# Altium schematic sheet Schematic.SchDoc(Schematic)
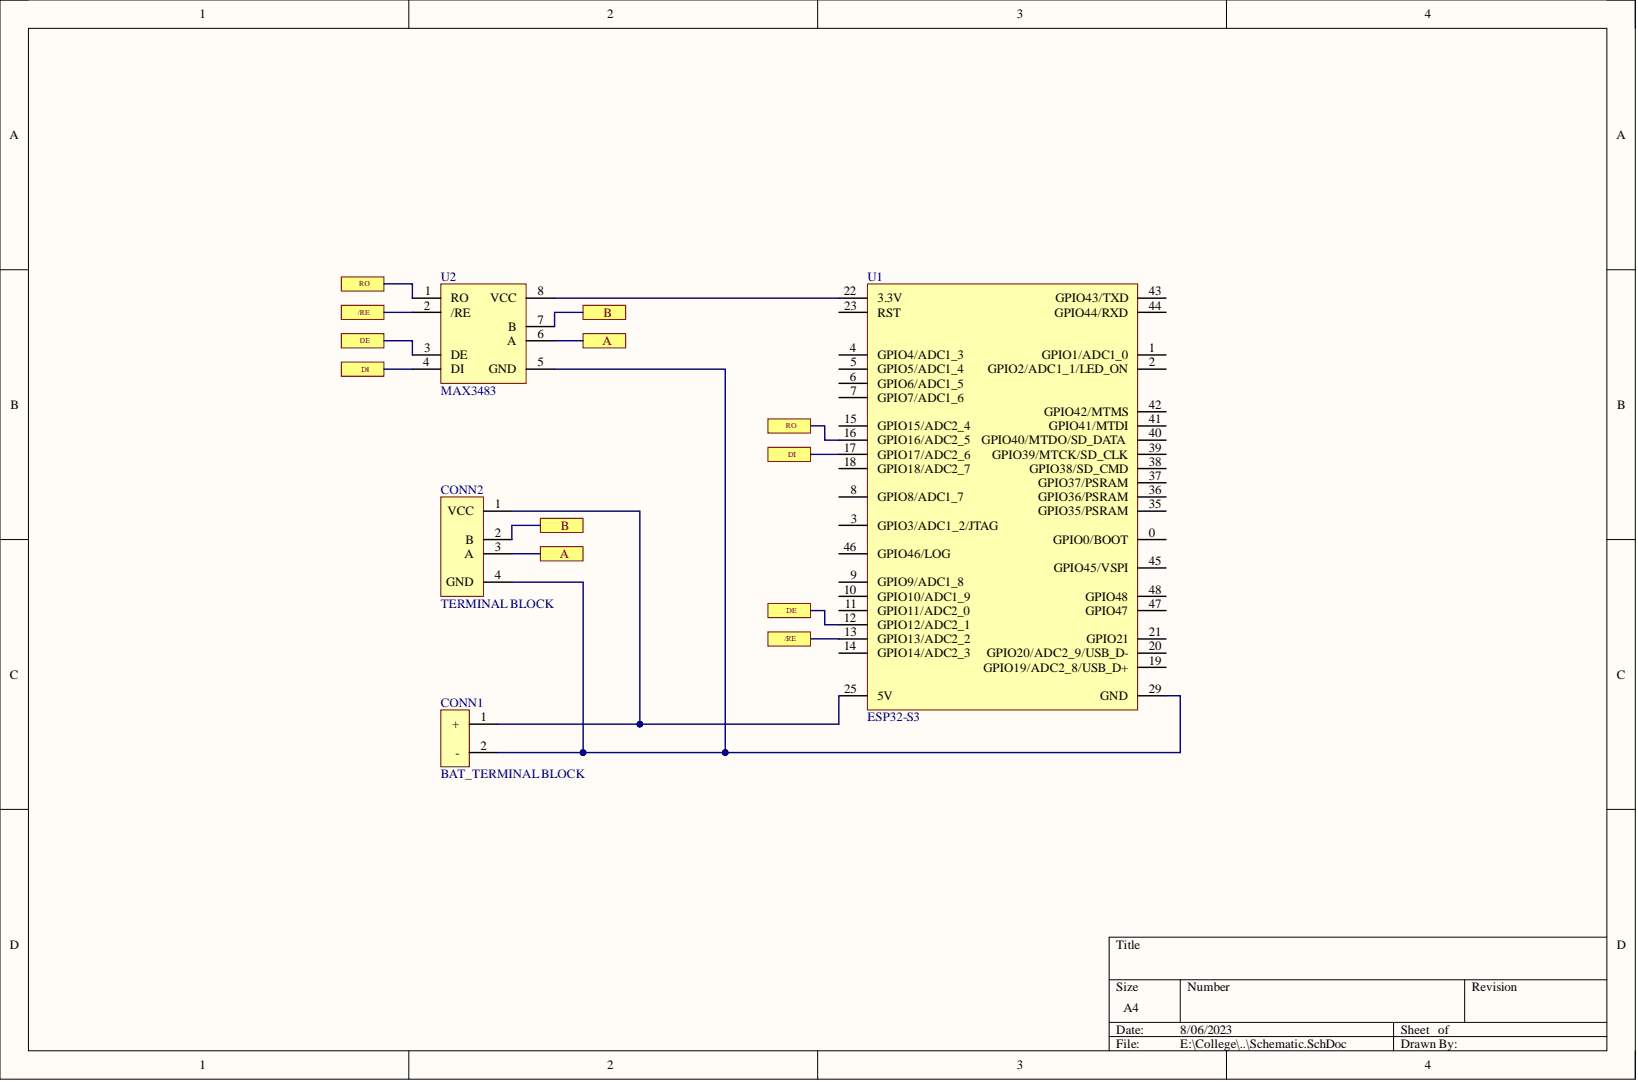
[diagram p0: Schematic.SchDoc]

## Parts
CONN1  BAT_TERMINAL BLOCK  [TERM_BLOCK2]
CONN2  TERMINAL BLOCK  [TERM_BLOCK4]
U1  ESP32-S3  [ESP32_FOOT]
U2  MAX3483  [MAX3483_FOOT]

## Ports
/RE, A, B, DE, DI, RO

## Nets
/RE: U1.13(GPIO13/ADC2_2) U2.2(/RE) ; port /RE
A: CONN2.3(A) U2.6(A) ; port A
B: CONN2.2(B) U2.7(B) ; port B
DE: U1.12(GPIO12/ADC2_1) U2.3(DE) ; port DE
DI: U1.17(GPIO17/ADC2_6) U2.4(DI) ; port DI
RO: U1.16(GPIO16/ADC2_5) U2.1(RO) ; port RO
NetCONN1_1: CONN1.1 CONN2.1(VCC) U1.25(5V)
NetCONN1_2: CONN1.2 CONN2.4(GND) U1.29(GND) U2.5(GND)
NetU1_22: U1.22(3.3V) U2.8(VCC)

Not connected: U1 0(GPIO0/BOOT) 1(GPIO1/ADC1_0) 2(GPIO2/ADC1_1/LED_ON) 3(GPIO3/ADC1_2/JTAG) 4(GPIO4/ADC1_3) 5(GPIO5/ADC1_4) 6(GPIO6/ADC1_5) 7(GPIO7/ADC1_6) 8(GPIO8/ADC1_7) 9(GPIO9/ADC1_8) 10(GPIO10/ADC1_9) 11(GPIO11/ADC2_0) 14(GPIO14/ADC2_3) 15(GPIO15/ADC2_4) 18(GPIO18/ADC2_7) 19(GPIO19/ADC2_8/USB_D+) 20(GPIO20/ADC2_9/USB_D-) 21(GPIO21) 23(RST) 35(GPIO35/PSRAM) 36(GPIO36/PSRAM) 37(GPIO37/PSRAM) 38(GPIO38/SD_CMD) 39(GPIO39/MTCK/SD_CLK) 40(GPIO40/MTDO/SD_DATA) 41(GPIO41/MTDI) 42(GPIO42/MTMS) 43(GPIO43/TXD) 44(GPIO44/RXD) 45(GPIO45/VSPI) 46(GPIO46/LOG) 47(GPIO47) 48(GPIO48)

## Library data of the parts
CONN1 = BAT_TERMINAL_BLOCK: Manufacterer: Weidmuller; Manufacterer Part No: 1760510000; Component Kind: Standard; Library Name: Schlib.SchLib
CONN2 = TERMINAL_BLOCK: Manufacterer: Weismuller; Manufacterer Part No: 9993920000; Component Kind: Standard; Library Name: Schlib.SchLib
U1 = ESP32_S3: Manufacterer: Freenove; Manufacterer Part No: ESP32-S3-WROOM Board; Component Kind: Standard; Library Name: Schlib.SchLib
U2 = MAX3483: Manufacterer: Analog Devices Inc; Manufacterer Part No: MAX3483ESA+; Component Kind: Standard; Library Name: Schlib.SchLib
